# Altium schematic sheet LeoBlinky2018.SchDoc
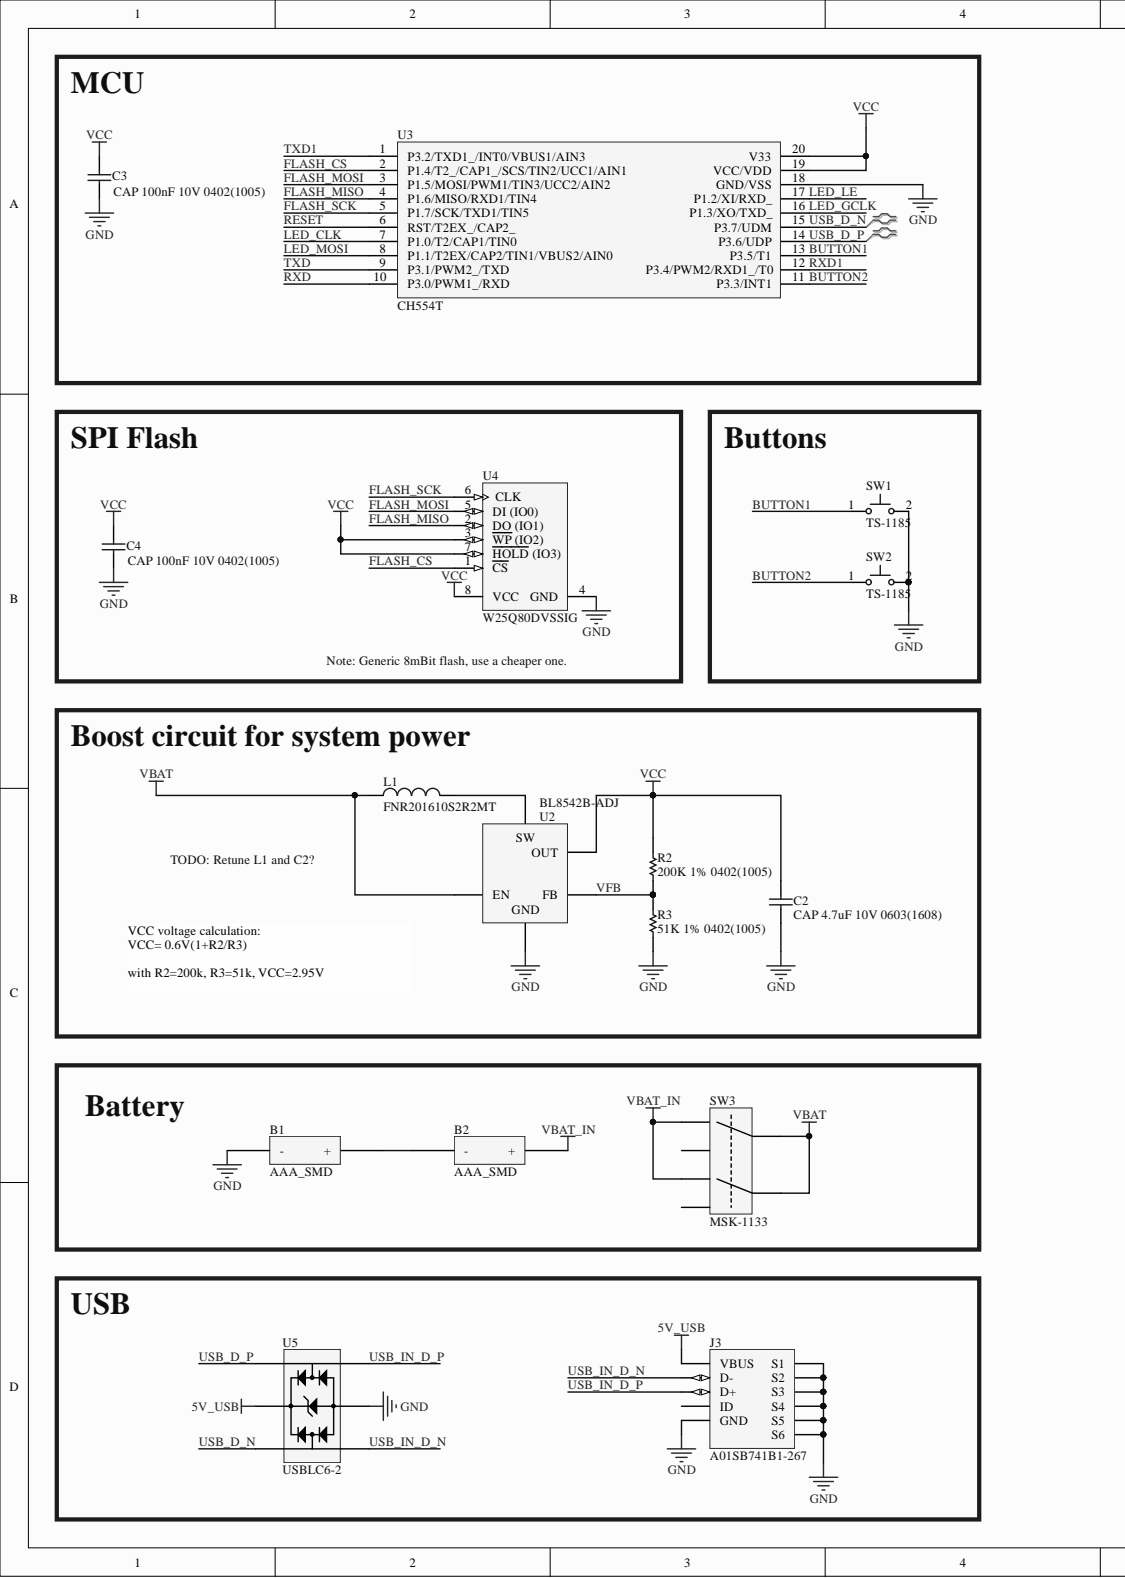
[diagram p0: LeoBlinky2018.SchDoc, left]
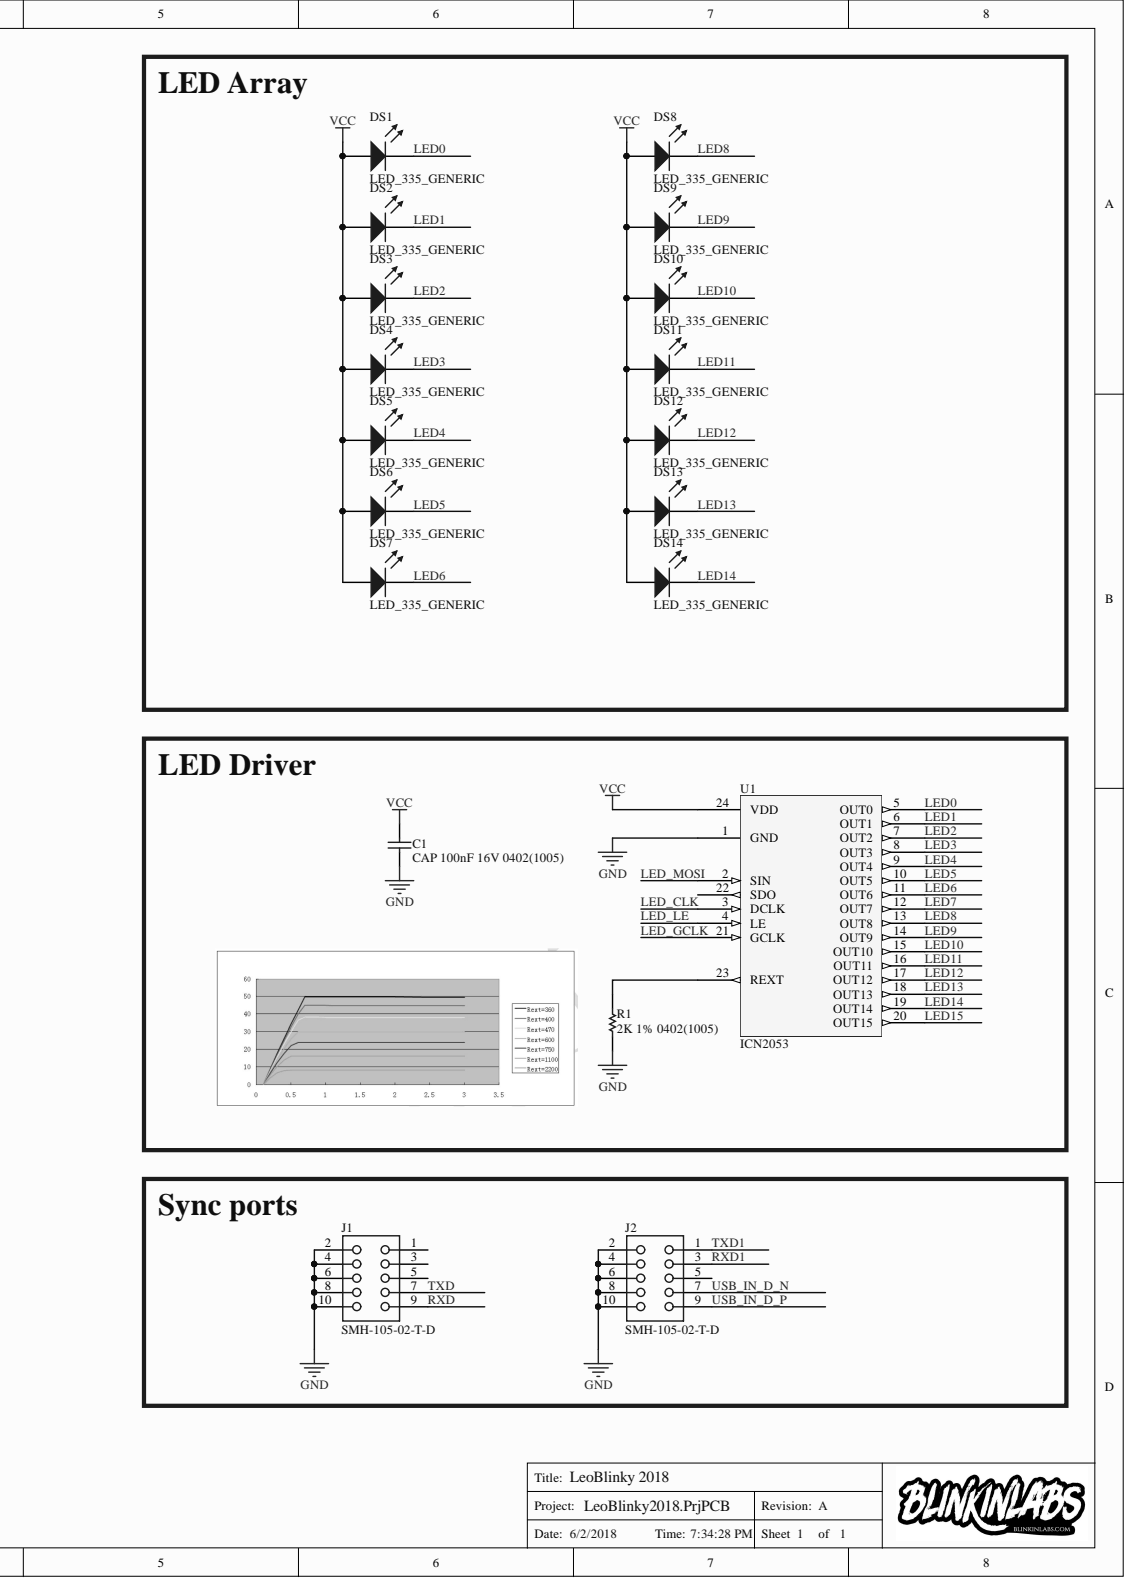
[diagram p1: LeoBlinky2018.SchDoc, right]

## Parts
B1  AAA_SMD  [AAA_SMD]
B2  AAA_SMD  [AAA_SMD]
C1  CAP 100nF 16V 0402(1005)  value 100nF  [CAPC0402(1005)60_N]
C2  CAP 4.7uF 10V 0603(1608)  value 4.7uF  [CAPC0603(1608)55_L]
C3  CAP 100nF 10V 0402(1005)  value 100nF  [CAPC0402(1005)60_L]
C4  CAP 100nF 10V 0402(1005)  value 100nF  [CAPC0402(1005)60_L]
DS1  LED_335_GENERIC  [LED_335_GENERIC]
DS2  LED_335_GENERIC  [LED_335_GENERIC]
DS3  LED_335_GENERIC  [LED_335_GENERIC]
DS4  LED_335_GENERIC  [LED_335_GENERIC]
DS5  LED_335_GENERIC  [LED_335_GENERIC]
DS6  LED_335_GENERIC  [LED_335_GENERIC]
DS7  LED_335_GENERIC  [LED_335_GENERIC]
DS8  LED_335_GENERIC  [LED_335_GENERIC]
DS9  LED_335_GENERIC  [LED_335_GENERIC]
DS10  LED_335_GENERIC  [LED_335_GENERIC]
DS11  LED_335_GENERIC  [LED_335_GENERIC]
DS12  LED_335_GENERIC  [LED_335_GENERIC]
DS13  LED_335_GENERIC  [LED_335_GENERIC]
DS14  LED_335_GENERIC  [LED_335_GENERIC]
J1  SMH-105-02-T-D  [SMTC-SMH-105-02-X-D]
J2  SMH-105-02-T-D  [SMTC-SMH-105-02-X-D]
J3  A01SB741B1-267  [A01SB741B1-267]
L1  FNR201610S2R2MT  [FNR201610S]
R1  2K 1% 0402(1005)  [RESC0402(1005)_N]
R2  200K 1% 0402(1005)  [RESC0402(1005)_L]
R3  51K 1% 0402(1005)  [RESC0402(1005)_L]
SW1  TS-1185  [TS-1185]
SW2  TS-1185  [TS-1185]
SW3  MSK-1133  [MSK-1133-NOHOLE]
U1  ICN2053  [SOP64P600X175-24N]
U2  BL8542B-ADJ  [SOT95P280X130-5N]
U3  CH554T  [TSOP65P660X110-20N]
U4  W25Q80DVSSIG  [WINB-SOIC-8_L]
U5  USBLC6-2  [SOT95P280X145-6N]

## Nets
5V_USB: J3.VBUS U5.5
GND: B1.2 C1.1 C2.1 C3.1 C4.1 J1.2 J1.4 J1.6 J1.8 J1.10 J2.2 J2.4 J2.6 J2.8 J2.10 J3.GND J3.S1 J3.S2 J3.S3 J3.S4 J3.S5 J3.S6 R1.1 R3.1 SW1.2 SW2.2 U1.1(GND) U2.2 U3.18(GND/VSS) U4.4(GND) U5.2
VBAT: L1.1 SW3.2 SW3.5 U2.3(EN)
VBAT_IN: B2.1 SW3.1 SW3.6
VCC: C1.2 C2.2 C3.2 C4.2 DS1.2 DS2.2 DS3.2 DS4.2 DS5.2 DS6.2 DS7.2 DS8.2 DS9.2 DS10.2 DS11.2 DS12.2 DS13.2 DS14.2 R2.2 U1.24(VDD) U2.5(OUT) U3.19(VCC/VDD) U3.20(V33) U4.3(WP_(IO2)) U4.7(HOLD_(IO3)) U4.8(VCC)
BUTTON1: SW1.1 U3.13(P3.5/T1)
BUTTON2: SW2.1 U3.11(P3.3/INT1)
FLASH_CS: U3.2(P1.4/T2_/CAP1_/SCS/TIN2/UCC1/AIN1) U4.1(CS)
FLASH_MISO: U3.4(P1.6/MISO/RXD1/TIN4) U4.2(DO_(IO1))
FLASH_MOSI: U3.3(P1.5/MOSI/PWM1/TIN3/UCC2/AIN2) U4.5(DI_(IO0))
FLASH_SCK: U3.5(P1.7/SCK/TXD1/TIN5) U4.6(CLK)
LED0: DS1.1 U1.5(OUT0)
LED1: DS2.1 U1.6(OUT1)
LED2: DS3.1 U1.7(OUT2)
LED3: DS4.1 U1.8(OUT3)
LED4: DS5.1 U1.9(OUT4)
LED5: DS6.1 U1.10(OUT5)
LED6: DS7.1 U1.11(OUT6)
LED7: U1.12(OUT7)
LED8: DS8.1 U1.13(OUT8)
LED9: DS9.1 U1.14(OUT9)
LED10: DS10.1 U1.15(OUT10)
LED11: DS11.1 U1.16(OUT11)
LED12: DS12.1 U1.17(OUT12)
LED13: DS13.1 U1.18(OUT13)
LED14: DS14.1 U1.19(OUT14)
LED15: U1.20(OUT15)
LED_CLK: U1.3(DCLK) U3.7(P1.0/T2/CAP1/TIN0)
LED_GCLK: U1.21(GCLK) U3.16(P1.3/XO/TXD_)
LED_LE: U1.4(LE) U3.17(P1.2/XI/RXD_)
LED_MOSI: U1.2(SIN) U3.8(P1.1/T2EX/CAP2/TIN1/VBUS2/AIN0)
RESET: U3.6(RST/T2EX_/CAP2_)
RXD: J1.9 U3.10(P3.0/PWM1_/RXD)
RXD1: J2.3 U3.12(P3.4/PWM2/RXD1_/T0)
TXD: J1.7 U3.9(P3.1/PWM2_/TXD)
TXD1: J2.1 U3.1(P3.2/TXD1_/INT0/VBUS1/AIN3)
USB_D_N: U3.15(P3.7/UDM) U5.6
USB_D_P: U3.14(P3.6/UDP) U5.4
USB_IN_D_N: J2.7 J3.D- U5.1
USB_IN_D_P: J2.9 J3.D+ U5.3
VFB: R2.1 R3.2 U2.1(FB)
NetB1_1: B1.1 B2.2
NetL1_2: L1.2 U2.4(SW)
NetR1_2: R1.2 U1.23(REXT)

Not connected: J1 1 3 5; J2 5; J3 ID; SW3 3 4; U1 22(SDO)

## Library data of the parts
B1 B2 = AAA_SMD: AAA battery holder, surface mount.; Component Kind: Standard; Library Name: Blinkinlabs.IntLib
C1 = CMP-1034-02071-1: CAP 100nF 16V -20% to +80% 0402 (1005 Metric) Thickness 0.6mm SMD; Case-EIA: 0402; Case-Metric: 1005; Component Kind: Standard; Library Name: Altium Content Vault; Max Thickness: 0.6 mm; Rated Voltage: 16 V; Technology: SMT; Tolerance: -20% to +80%
C2 = CMP-1035-02784-1: CAP 4.7uF 10V ±10% 0603 (1608 Metric) Thickness 0.55mm SMD; Case-EIA: 0603; Case-Metric: 1608; Tolerance: Â±10%; Component Kind: Standard; Library Name: Altium Content Vault; Max Thickness: 0.55 mm; Rated Voltage: 10 V; Technology: SMT
C3 C4 = CMP-1034-02065-1: CAP 100nF 10V ±10% 0402 (1005 Metric) Thickness 0.6mm SMD; Case-EIA: 0402; Case-Metric: 1005; Tolerance: Â±10%; Component Kind: Standard; Library Name: Altium Content Vault; Max Thickness: 0.6 mm; Rated Voltage: 10 V; Technology: SMT
DS1-DS14 = LED_335_GENERIC: SMD LED, side visible, generic; Component Kind: Standard; Library Name: Blinkinlabs.IntLib
J1 J2 = CMP-1023-00158-1: SMT Horizontal Socket, -55 to 105 degC, 2.54 mm Pitch, 10-Pin, Female, RoHS; Manufacturer: Samtec; Pitch: 2.54 mm; Component Kind: Standard; ComponentLink1Description: Manufacturer URL; ComponentLink1URL: http://www.samtec.com; ComponentLink2Description: Datasheet; ComponentLink2URL: http://www.samtec.com/documents/webfiles/pdf/SMH.PDF; ComponentLink3Description: Package Specification; ComponentLink3URL: http://www.samtec.com/documents/webfiles/cpdf/SMH-1XX-XX-XX-X-XX-MKT.pdf; DatasheetVersion: F-214; Library Name: Altium Content Vault; Mounting Technology: Surface Mount; Orientation: Horizontal; PackageDescription: .100 [2.54] Horizontal SMT Socket Assembly; PackageReference: SMH-105-02-X-D; PackageVersion: AF, 2/1999; PartNumber: SMH-105-02-T-D; RoHS: True; Temperature Range: -55 to 105 degC
J3 = A01SB741B1-267: Micro USB B type 四脚全插牛角; Component Kind: Standard; Library Name: Blinkinlabs.IntLib
L1 = FNR201610S2R2MT: Wire Wound SMD Power Inductors 2.0×1.6×1.0 2.2uH; Component Kind: Standard; Library Name: Blinkinlabs.IntLib
R1 = CMP-1011-00542-1: 2K 0.063W 1% 0402 (1005 Metric)  SMD; Case-EIA: 0402; Case-Metric: 1005; Value: 2000.00 Ohm; Component Kind: Standard; Library Name: Altium Content Vault; Power: 0.063 W; Technology: SMT; Tolerance: 1 %
R2 = CMP-1011-00768-1: 200K 0.063W 1% 0402 (1005 Metric)  SMD; Case-EIA: 0402; Case-Metric: 1005; Value: 200000.00 Ohm; Component Kind: Standard; Library Name: Altium Content Vault; Power: 0.063 W; Technology: SMT; Tolerance: 1 %
R3 = CMP-1011-00703-1: 51K 0.063W 1% 0402 (1005 Metric)  SMD; Case-EIA: 0402; Case-Metric: 1005; Value: 51000.00 Ohm; Component Kind: Standard; Library Name: Altium Content Vault; Power: 0.063 W; Technology: SMT; Tolerance: 1 %
SW1 SW2 = TS-1185: Tact Switch, 3x4mm, no alignment pin, turtle style; Component Kind: Standard; Library Name: Blinkinlabs.IntLib
SW3 = MSK-1133: SMD micro toggle switch, 2 position, 2 circuit; Component Kind: Standard; Library Name: Blinkinlabs.IntLib
U1 = ICN2053: 16-Channel PWM Constant Current LED Sink Driver; Manufacturer: ChipOne; Component Kind: Standard; PartNumber: ICN2053; Library Name: Blinkinlabs.IntLib
U2 = BL8542B-ADJ: 0.85V startup, 1MHz, 300mA Iout,  Synchronous Boost Converter (adjustable output); Component Kind: Standard; Library Name: Blinkinlabs.IntLib
U3 = CH554T: 8-bit enhanced USB microcontroller; Manufacturer: WCH; Component Kind: Standard; PartNumber: CH554T; Library Name: Blinkinlabs.IntLib
U4 = CMP-2000-07408-1: Serial Flash Memory with Dual and Quad SPI, 8 Mbits, 2.7 to 3.6 V, -40 to 85 degC, 8-Pin SOIC (SS), RoHS, Tube; Manufacturer: WinbondElectronics; Interface: SPISerial; Memory Size: 8M(1Mx8); Memory Type: FLASH-NOR; Speed: 104MHz; Voltage - Supply: 2.7V~3.6V; Category: IntegratedCircuits(ICs); Component Kind: Standard; Datasheet URL: http://www.winbond.com/resource-files/w25q80dv_revg_07212015.pdf?__locale=zh_TW; Datasheet Version: Rev. G, 07/2015; Family: Memory; Format - Memory: FLASH; Library Name: Altium Content Vault; Manufacturer URL: http://www.winbond.com/hq/index.html?__locale=en; Mounting Technology: SurfaceMount; Operating Temperature: -40°C~85°C(TA); Package Description: 8-Pin Small Outline Integrated Circuit, Body 5.28 x 5.28 mm, Pitch 1.27 mm; Package Reference: SS-8; Packaging: Tube; Series: SpiFlash®; Supplier Device Package: 8-SOIC
U5 = USBLC6-2: Very low capacitance ESD protection; Component Kind: Standard; Library Name: Blinkinlabs.IntLib
